# Altium schematic sheet DoorCard.SchDoc
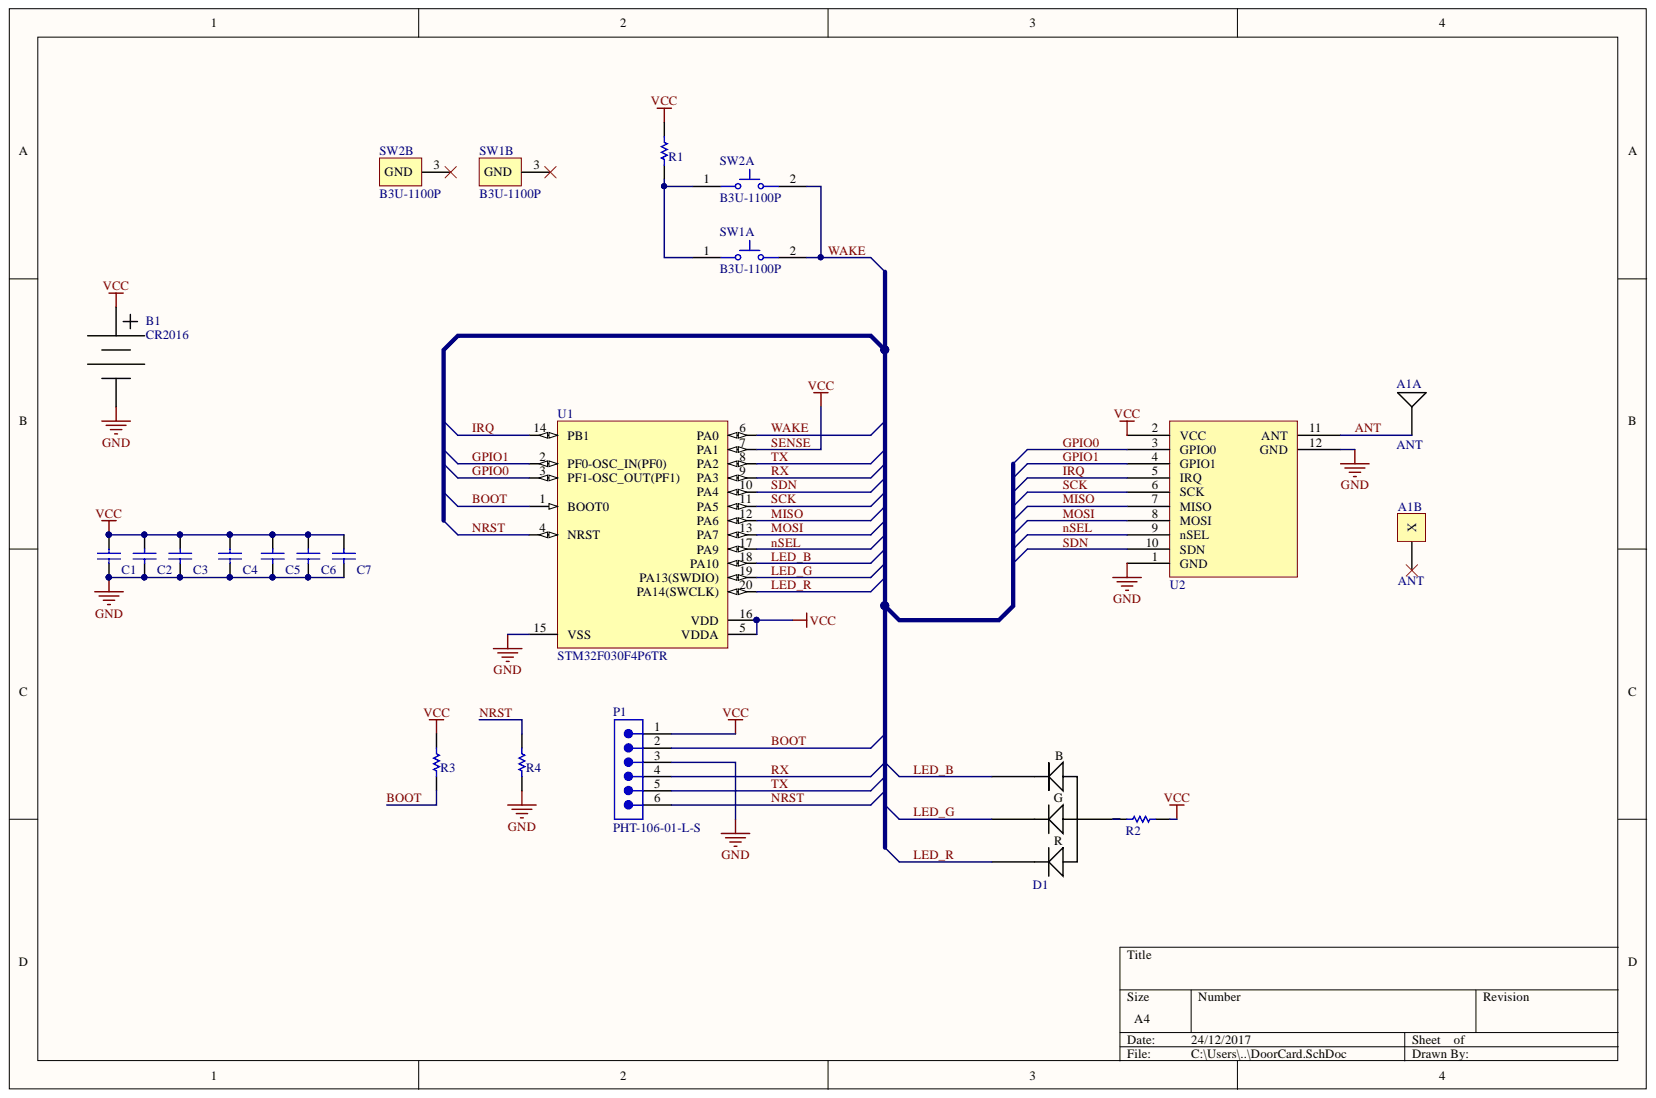
[diagram p0: DoorCard.SchDoc]

## Parts
A1  ANT  [433MHz_Antenna]  (parts A,B)
B1  CR2016  [CR2012]
C1  CAP 100nF 6.3V 0402(1005)  [CAPC0402(1005)60_N]  (value not drawn)
C2  CAP 100nF 6.3V 0402(1005)  [CAPC0402(1005)60_N]  (value not drawn)
C3  CAP 100nF 6.3V 0402(1005)  [CAPC0402(1005)60_N]  (value not drawn)
C4  CAP 47uF 6.3V 1206(3216)  [CAPC1206(3216)190_M]  (value not drawn)
C5  CAP 47uF 6.3V 1206(3216)  [CAPC1206(3216)190_M]  (value not drawn)
C6  CAP 47uF 6.3V 1206(3216)  [CAPC1206(3216)190_M]  (value not drawn)
C7  CAP 47uF 6.3V 1206(3216)  [CAPC1206(3216)190_M]  (value not drawn)
D1  ASMB-MTB0-0A3A2  [ASMB-MTB0-0A3A2]  (value not drawn)
P1  PHT-106-01-L-S  [SMTC-PHT-106-01-X-S]
R1  10K 1% 0402(1005)  [RESC0402(1005)_N]  (value not drawn)
R2  10K 1% 0402(1005)  [RESC0402(1005)_N]  (value not drawn)
R3  10K 1% 0402(1005)  [RESC0402(1005)_N]  (value not drawn)
R4  10K 1% 0402(1005)  [RESC0402(1005)_N]  (value not drawn)
SW1  B3U-1100P  [OMRON-B3U-1100P_V]  (parts A,B)
SW2  B3U-1100P  [OMRON-B3U-1100P_V]  (parts A,B)
U1  STM32F030F4P6TR  [STM-TSSOP20_M]
U2  Si4463  [E10-433MS]  (value not drawn)

## Nets
GND: B1.2 C1.1 C2.1 C3.1 C4.1 C5.1 C6.1 C7.1 P1.3 R4.2 U1.15(VSS) U2.1(GND) U2.12(GND)
ANT: A1.1 U2.11(ANT)
BOOT: P1.2 R3.2 U1.1(BOOT0)
GPIO0: U1.3(PF1-OSC_OUT(PF1)) U2.3(GPIO0)
GPIO1: U1.2(PF0-OSC_IN(PF0)) U2.4(GPIO1)
IRQ: U1.14(PB1) U2.5(IRQ)
LED_B: D1.2 U1.18(PA10)
LED_G: D1.3 U1.19(PA13(SWDIO))
LED_R: D1.4 U1.20(PA14(SWCLK))
MISO: U1.12(PA6) U2.7(MISO)
MOSI: U1.13(PA7) U2.8(MOSI)
NRST: P1.6 R4.1 U1.4(NRST)
nSEL: U1.17(PA9) U2.9(nSEL)
RX: P1.4 U1.9(PA3)
SCK: U1.11(PA5) U2.6(SCK)
SDN: U1.10(PA4) U2.10(SDN)
SENSE: B1.1 C1.2 C2.2 C3.2 C4.2 C5.2 C6.2 C7.2 P1.1 R1.1 R2.1 R3.1 U1.5(VDDA) U1.7(PA1) U1.16(VDD) U2.2(VCC)
TX: P1.5 U1.8(PA2)
WAKE: SW1.2 SW2.2 U1.6(PA0)
NetD1_1: D1.1 R2.2
NetR1_2: R1.2 SW1.1 SW2.1
N00012:

Not connected: A1 2(X); SW1 3(GND); SW2 3(GND)

## Library data of the parts
A1 = ANT1204F002R0433A: Component Kind: Standard; Description: ANT1204F002R0433A; Library Name: Antenna.SchLib
B1 = BAT-HLD-002-SMT: Component Kind: Standard; Description: BAT-HLD-002-SMT; Library Name: Cell.SchLib
C1-C3 = CMP-1034-02063-1: CAP 100nF 6.3V -20% to +80% 0402 (1005 Metric) Thickness 0.6mm SMD; Case-EIA: 0402; Case-Metric: 1005; Component Kind: Standard; Library Name: Altium Content Vault; Max Thickness: 0.6 mm; Rated Voltage: 6.3 V; Technology: SMT; Tolerance: -20% to +80%; Value: 100nF
C4-C7 = CMP-1037-05000-1: CAP 47uF 6.3V ±20% 1206 (3216 Metric) Thickness 1.9mm SMD; Case-EIA: 1206; Case-Metric: 3216; Tolerance: Â±20%; Component Kind: Standard; Library Name: Altium Content Vault; Max Thickness: 1.9 mm; Rated Voltage: 6.3 V; Technology: SMT; Value: 47uF
D1 = ASMB-MTB0-0A3A2: RGB LED; Component Kind: Standard; Library Name: LED.SchLib
P1 = CMP-1024-00187-1: Press Fit Header, Through-hole, Vertical, -55 to 125 degC, 2.54 mm Pitch, 6-Pin, Male, RoHS; Manufacturer: Samtec; Pitch: 2.54 mm; Component Kind: Standard; ComponentLink1Description: Manufacturer URL; ComponentLink1URL: http://www.samtec.com; ComponentLink2Description: Datasheet; ComponentLink2URL: http://www.samtec.com/documents/webfiles/pdf/pht.pdf; ComponentLink3Description: Package Specification; ComponentLink3URL: http://www.samtec.com/documents/webfiles/cpdf/PHT-1XX-XX-X-X-XXX-MKT.pdf; DatasheetVersion: F-214; Library Name: Altium Content Vault; Mounting Technology: Through-hole; Orientation: Vertical; PackageDescription: .100 Press Fit Socket Assembly; PackageReference: PHT-106-01-X-S; PackageVersion: J, 9/2009; PartNumber: PHT-106-01-L-S; RoHS: True; Temperature Range: -55 to 125 degC
R1-R4 = CMP-1011-00623-1: 10K 0.063W 1% 0402 (1005 Metric)  SMD; Case-EIA: 0402; Case-Metric: 1005; Value: 10000.00 Ohm; Component Kind: Standard; Library Name: Altium Content Vault; Power: 0.063 W; Technology: SMT; Tolerance: 1 %
SW1 SW2 = CMP-2000-05903-1: Ultra-Small Tactile Switch, SPST-NO, 50 mA, 12 V, -25 to 70 degC, 3-Pin SMD, RoHS, Tape and Reel; Manufacturer: OmronElectronics; Actuator Length: 0.4mm; Actuator Style: RoundButton; Body Orientation: Straight; Contact Rating @ Voltage: 0.05@12VDCA; Dielectric Strength: 250VAC; Electrical Life: 200000Cycles; Ground Terminal: Yes; Illumination Type: N/R; Maximum Contact Resistance: 100mOhm; Maximum DC Voltage Rating: 12V; Operating Force: 1.5N; Pole Throw Configuration: SPST; Product Weight: 0.022g; Switch Configuration: N.O.; Actuator Color: N/A; Base Material: N/A; Component Kind: Standard; Contact Material: N/A; Contact Plating: N/A; Datasheet URL: http://www.omron.com/ecb/products/pdf/en-b3u.pdf; Datasheet Version: 01/2015; Dimension: 3.15x4x1.6mm; Family: B3U; Housing Material: N/A; Library Name: Altium Content Vault; Manufacturer URL: http://www.omron.com/; Maximum AC Voltage Rating: N/A; Mechanical Life: N/A; Minimum Insulation Resistance: 100MOhm; Mounting: SurfaceMount,GullWing; Mounting Technology: SurfaceMount; Operating Temperature: -25to70C; Package Description: 3-Pin Surface Monut Device, Body 3 x 2.5 mm; Package Reference: B3U-1100P; Packaging: EmbossedTapeandReel; PartId: 1; Part No: B3U-1100P; RoHS: Yes; Seal Description: N/A; Seal Location: N/A; Type: N/A
U1 = CMP-0304-00066-1: ARM Cortex-M0 32-bit MCU, 16 KB Flash, 4 KB Internal RAM, 15 I/Os, 20-Pin TSSOP, -40 to 85 degC, Tape and Reel; FLASH Size (Prog) (kB): 16; I/Os (High Current): 15; Internal RAM Size (kB): 4; Operating Frequency(F) (Processor speed) (MHz): 48; Supply Voltage(Vcc) min (V): 2.4; Component Kind: Standard; ComponentLink1Description: Manufacturer URL; ComponentLink1URL: http://www.st.com/; ComponentLink2Description: Datasheet; ComponentLink2URL: http://www.st.com/st-web-ui/static/active/en/resource/technical/document/datasheet/DM00088500.pdf; Core: ARM Cortex-M0; DatasheetVersion: Rev. 2; Library Name: Altium Content Vault; Mounting Technology: Surface Mount; Package Description: 20-Pin Thin Shrink Small Outline Package, Body 6.5 x 4.4 mm, Pitch 0.65 mm; Package Reference: TSSOP20; Packing: Tape and Reel
U2 = Component_1: E10-433MS; Component Kind: Standard; Library Name: SiliconLabs.SchLib
